# Altium schematic sheet CAN.SchDoc
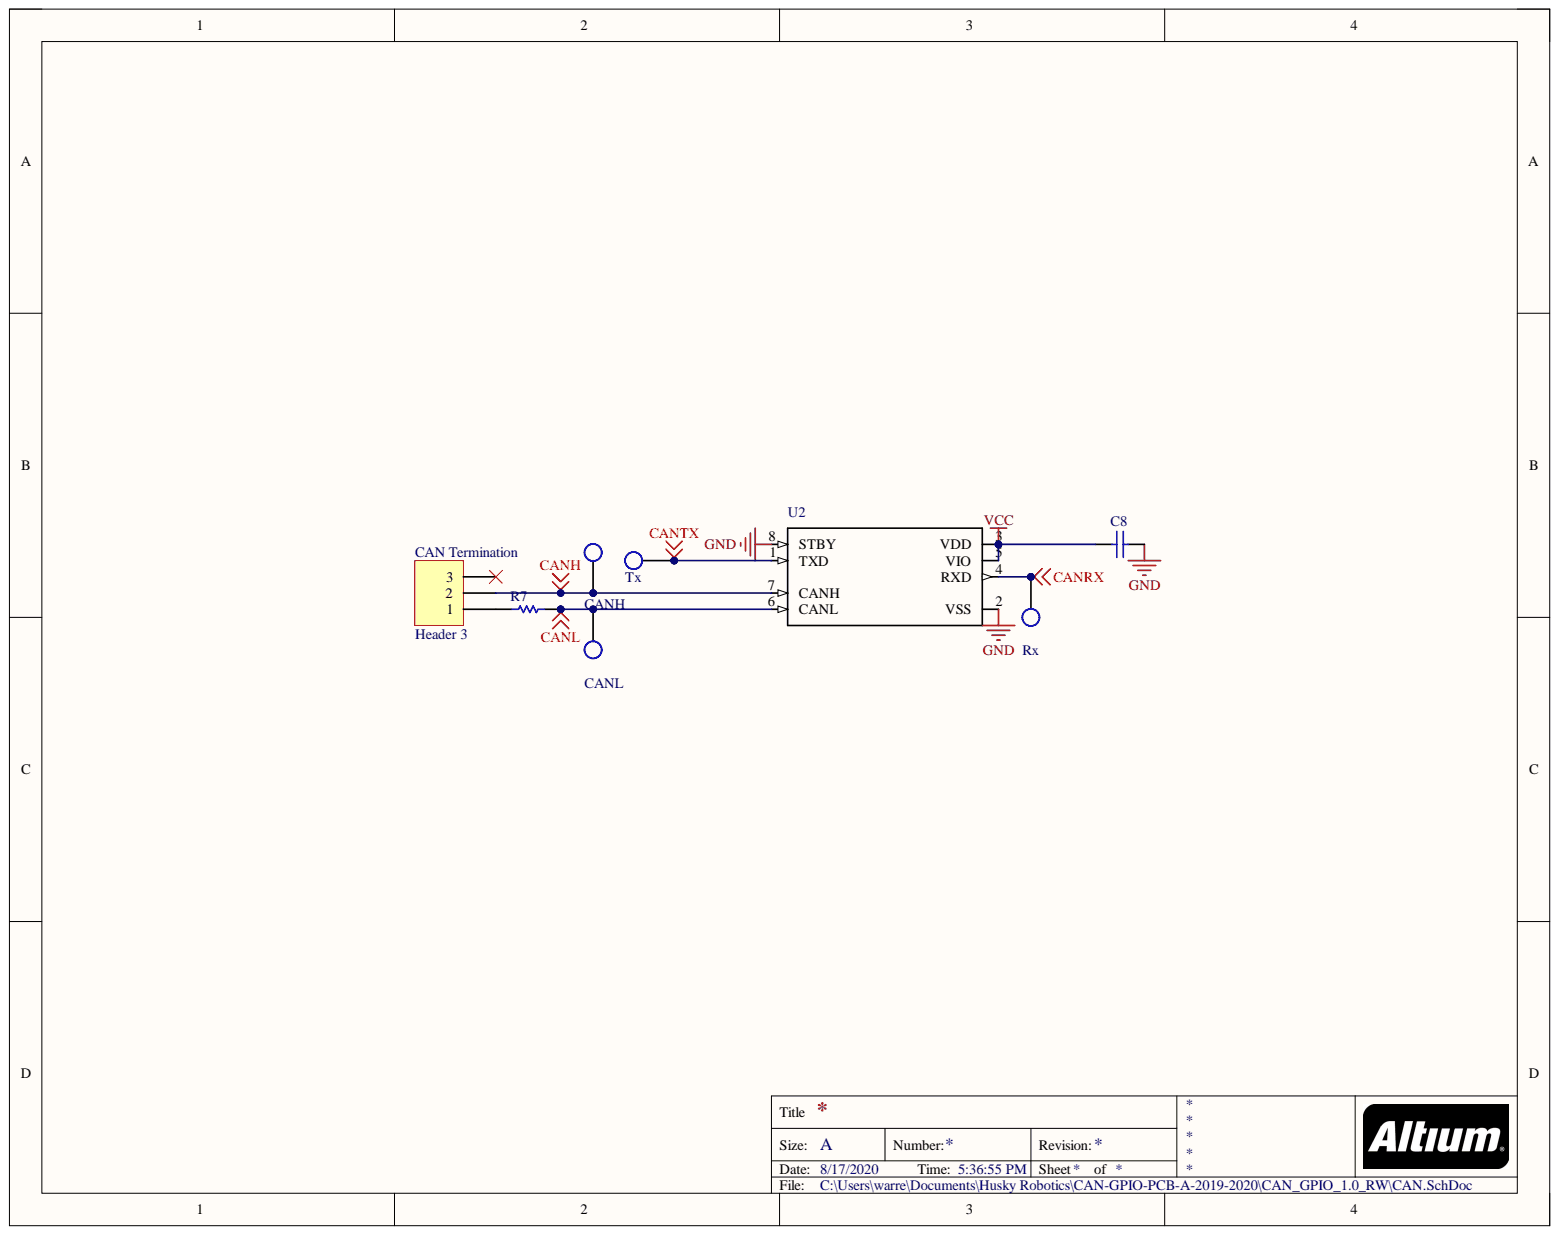
[diagram p0: CAN.SchDoc]

## Parts
C8  0.1 μF  [Cap 0805]  (value not drawn)
CAN_Termination  Header 3  [HDR1X3]
CANH  Test Point  [Test Point pad]  (value not drawn)
CANL  Test Point  [Test Point pad]  (value not drawn)
R7  Resistor 0805  [RESC0805(2012)_N]  (value not drawn)
Rx  Test Point  [Test Point Through-hole]  (value not drawn)
Tx  Test Point  [Test Point Through-hole]  (value not drawn)
U2  MCP2562-E/SN  [SOIC127P600X175-8N]  (value not drawn)

## Nets
CANH: CAN_Termination.2 CANH.1 U2.7(CANH)
CANL: CANL.1 R7.1 U2.6(CANL)
CANRX: Rx.1 U2.4(RXD)
CANTX: Tx.1 U2.1(TXD)
GND: C8.2 U2.2(VSS) U2.8(STBY)
VCC: C8.1 U2.3(VDD) U2.5(VIO)
NetCAN Termination_1: CAN_Termination.1 R7.2

Not connected: CAN_Termination 3

## Library data of the parts
C8 = Capacitor 0805: Standard Capacitor; Capacitance: 0.1 μF; Case Size/Dimension: 0805; Component Kind: Standard; Library Name: Standard Cap.SchLib; Mounting Technology: SurfaceMount; Package Reference: 0805
CAN_Termination = Header 3: Header, 3-Pin; Component Kind: Standard; LatestRevisionDate: 17-Jul-2002; LatestRevisionNote: Re-released for DXP Platform.; Library Name: Miscellaneous Connectors.IntLib; Signal Integrity: Connector; Publisher: Altium Limited
R7 = Resistor 0805: Generic 0805 Resistor; Case-EIA: 0805; Case-Metric: 2012; Power: 0.125 W; Tolerance: 5 %; Value: 120R; Component Kind: Standard; Technology: SMT; Library Name: Husky Robotics Standard Library 2019-2020.IntLib
U2 = CAN Tranceiver: Microchip MCP2562-E/SN, CAN Transceiver 1MBps 1-channel IEC 61000-4-2, 8-Pin SOIC; Availability: Unavailable; Component Kind: Standard; MF: Microchip; MP: MCP2562-E/SN; Package: SO-8 Microchip; Price: None; SnapEDA_Link: https://www.snapeda.com/parts/MCP2562-E/SN/Microchip/view-part/?ref=snap; Library Name: Husky Robotics Standard Library 2019-2020.IntLib
CANH CANL Rx Tx = Test Point: Component Kind: Standard; Library Name: Husky Robotics Standard Library 2019-2020.IntLib
